# Altium schematic sheet Power.SchDoc(Power)
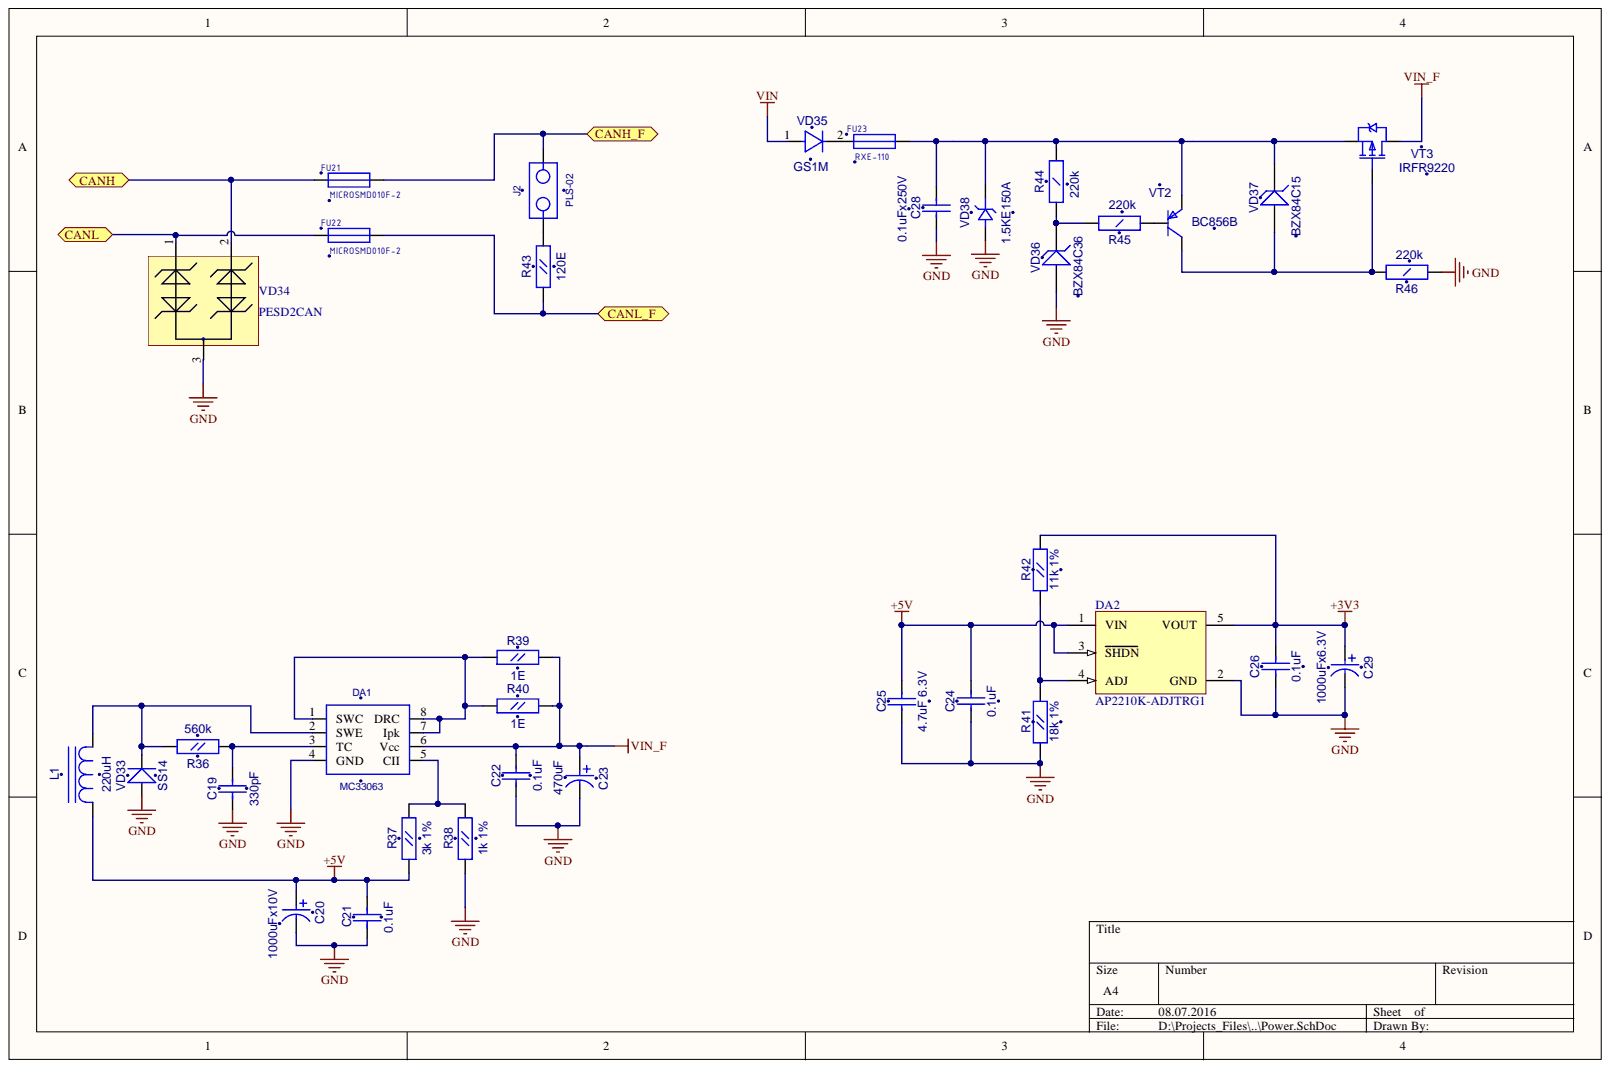
[diagram p0: Power.SchDoc]

## Parts
C19  330pF  [CC0603]
C20  1000uFx10V  [CC8X3.5]
C21  0.1uF  [CC0603]
C22  0.1uF  [CC0603]
C23  470uF  [CC16X10_CAP0.5(1)(Primary)]
C24  0.1uF  [CC0603]
C25  4.7uF 6.3V  [CC0805]
C26  0.1uF  [CC0603]
C28  0.1uFx250V  [CC1206]
C29  1000uFx6.3V  [CC8X3.5]
DA1  MC33063  [SO-8]
DA2  AP2210K-ADJTRG1  [SOT23-5]
FU21  MICROSMD010F-2  [RC1210]
FU22  MICROSMD010F-2  [RC1210]
FU23  RXE-110  [RXE-110]
J2  PLS-02  [PIN2W]
L1  220uH  [SDR0805]
R36  560k  [RC0603]
R37  3k 1%  [RC0603]
R38  1k 1%  [RC0603]
R39  1E  [RC0805]
R40  1E  [RC0805]
R41  18k 1%  [RC0603]
R42  11k 1%  [RC0603]
R43  120E  [RC0805]
R44  220k  [RC1206]
R45  220k  [RC1206]
R46  220k  [RC1206]
VD33  SS14  [SMA]
VD34  PESD2CAN  [SOT-23(123)]
VD35  GS1M  [SMA]
VD36  BZX84C36  [SOT-23(123)]
VD37  BZX84C15  [SOT-23(123)]
VD38  1.5KE150A  [DO-201]
VT2  BC856B  [SOT-23(123)]
VT3  IRFR9220  [D-PAK(TO-252AA)]

## Ports
CANH, CANH_F, CANL, CANL_F

## Nets
+3V3: C26.1 C29.1 DA2.5(VOUT) R42.2
+5V: C20.1 C21.2 C24.1 C25.2 DA2.1(VIN) DA2.3(SHDN) L1.1 R37.1
GND: C19.1 C20.2 C21.1 C22.1 C23.1 C24.2 C25.1 C26.2 C28.1 C29.2 DA1.4(GND) DA2.2(GND) R38.1 R41.1 R46.1 VD33.1 VD34.3 VD36.1 VD38.1
VIN: VD35.1
VIN_F: C22.2 C23.2 DA1.6(Vcc) R39.2 R40.2 VT3.3
CANH: FU21.1 VD34.2 ; port CANH
CANH_F: FU21.2 J2.2 ; port CANH_F
CANL: FU22.1 VD34.1 ; port CANL
CANL_F: FU22.2 R43.1 ; port CANL_F
NetC19_2: C19.2 DA1.3(TC) R36.1
NetC28_2: C28.2 FU23.2 R44.2 VD37.3 VD38.2 VT2.2 VT3.2
NetDA1_1: DA1.1(SWC) DA1.7(Ipk) DA1.8(DRC) R39.1 R40.1
NetDA1_2: DA1.2(SWE) L1.2 R36.2 VD33.2
NetDA1_5: DA1.5(CII) R37.2 R38.2
NetDA2_4: DA2.4(ADJ) R41.2 R42.1
NetFU23_1: FU23.1 VD35.2
NetJ2_1: J2.1 R43.2
NetR44_1: R44.1 R45.2 VD36.3
NetR45_1: R45.1 VT2.1
NetR46_2: R46.2 VD37.1 VT2.3 VT3.1

## Library data of the parts
C19 C21 C22 C24 C26 = CC0603: Component Kind: Standard; Description: CC0603; Library Name: gprs_modem_2.SchLib
  C19: Value: 330pF
  C21 C22 C24 C26: Value: 0.1uF
C20 C29 = CAP0.35: Component Kind: Standard; Description: CAP0.35; Library Name: gprs_modem_2.SchLib
  C20: Value: 1000uFx10V
  C29: Value: 1000uFx6.3V
C23 = CAP0.5(1): Component Kind: Standard; Description: CAP0.5(1); Value: 470uF; Library Name: gprs_modem_2.SchLib
C25 = CC0805: Component Kind: Standard; Description: CC0805; Value: 4.7uF 6.3V; Library Name: gprs_modem_2.SchLib
C28 = CC1206: Component Kind: Standard; Description: CC1206; Value: 0.1uFx250V; Library Name: gprs_modem_2.SchLib
DA1 = MC34063: Component Kind: Standard; Description: MC34063; Value: MC33063; Library Name: Schetchik.SchLib
DA2 = MCP1824T-ADJE/OT: 300 mA, Low Voltage, Low Quiescent Current LDO Regulator, 5-Pin SOT-223, Extended Temperature, Tape and Reel; Component Kind: Standard; ComponentLink1Description: Manufacturer Link; ComponentLink1URL: http://www.microchip.com; ComponentLink2Description: Datasheet; ComponentLink2URL: http://ww1.microchip.com/downloads/en/DeviceDoc/22070a.pdf; ComponentLink3Description: Packaging Specification; ComponentLink3URL: http://ww1.microchip.com/downloads/en/PackagingSpec/00049AW.pdf; DatasheetVersion: revA, Aug-2007; PackageDescription: 5-Lead Plastic Small Outline Transistor (DC) [SOT-223]; PackageReference: SOT-223-DC5; PackageVersion: revAW, Oct-2008; Published: 29-Jan-2009; Library Name: gprs_modem_2.SchLib; Publisher: Altium Limited
FU21 FU22 = MICROSMD: Component Kind: Standard; Description: MICROSMD; Value: MICROSMD010F-2; Library Name: gprs_modem_2.SchLib
L1 = SDR0805: Component Kind: Standard; Description: SDR0805; Value: 220uH; Library Name: gprs_modem.SchLib
R36-R38 R41 R42 = RC0603: Component Kind: Standard; Description: RC0603; Library Name: gprs_modem_2.SchLib
  R36: Value: 560k
  R37: Value: 3k 1%
  R38: Value: 1k 1%
  R41: Value: 18k 1%
  R42: Value: 11k 1%
R39 R40 R43 = RC0805: Component Kind: Standard; Description: RC0805; Library Name: gprs_modem_2.SchLib
  R39 R40: Value: 1E
  R43: Value: 120E
R44-R46 = RC1206: Component Kind: Standard; Description: RC1206; Value: 220k; Library Name: gprs_modem_2.SchLib
VD33 = SS14: Schottky Rectifier; Component Kind: Standard; Value: SS14; Library Name: gprs_modem.SchLib
VD34 = PESD2CAN: TVS for CAN; Component Kind: Standard; Library Name: gprs_modem.SchLib
VD35 = GS1M: Value: {Value}; Component Kind: Standard; Description: GS1M; Library Name: Schetchik.SchLib
VD36 VD37 = BZX84: Zener Voltage Regulator Diode; Component Kind: Standard; Library Name: gprs_modem_2.SchLib
  VD36: Value: BZX84C36
  VD37: Value: BZX84C15
VD38 = 1.5KE: Component Kind: Standard; Description: 1.5KE; Value: 1.5KE150A; Library Name: gprs_modem.SchLib
VT2 = BC856B: ComponentField7: Published 29-Sep-2000; OriginalName: BC856BLT; Component Kind: Standard; Description: BC856B; Simulation: BC846BLT1; Value: BC856B; Library Name: Schetchik.SchLib
VT3 = IRFR9220: HEXFET Power MOSFET; ComponentField7: Published 8-Jun-2000; OriginalName: IRLML5103; Component Kind: Standard; Value: IRFR9220; Library Name: gprs_modem.SchLib
FU23 = RXE-110: Component Kind: Standard; Description: RXE-110; Library Name: gprs_modem_2.SchLib
J2 = PLS-02: Component Kind: Standard; Description: PLS-02; Library Name: gprs_modem_2.SchLib
